# Altium schematic sheet Knot_Thing_NFC_NT3.SchDoc
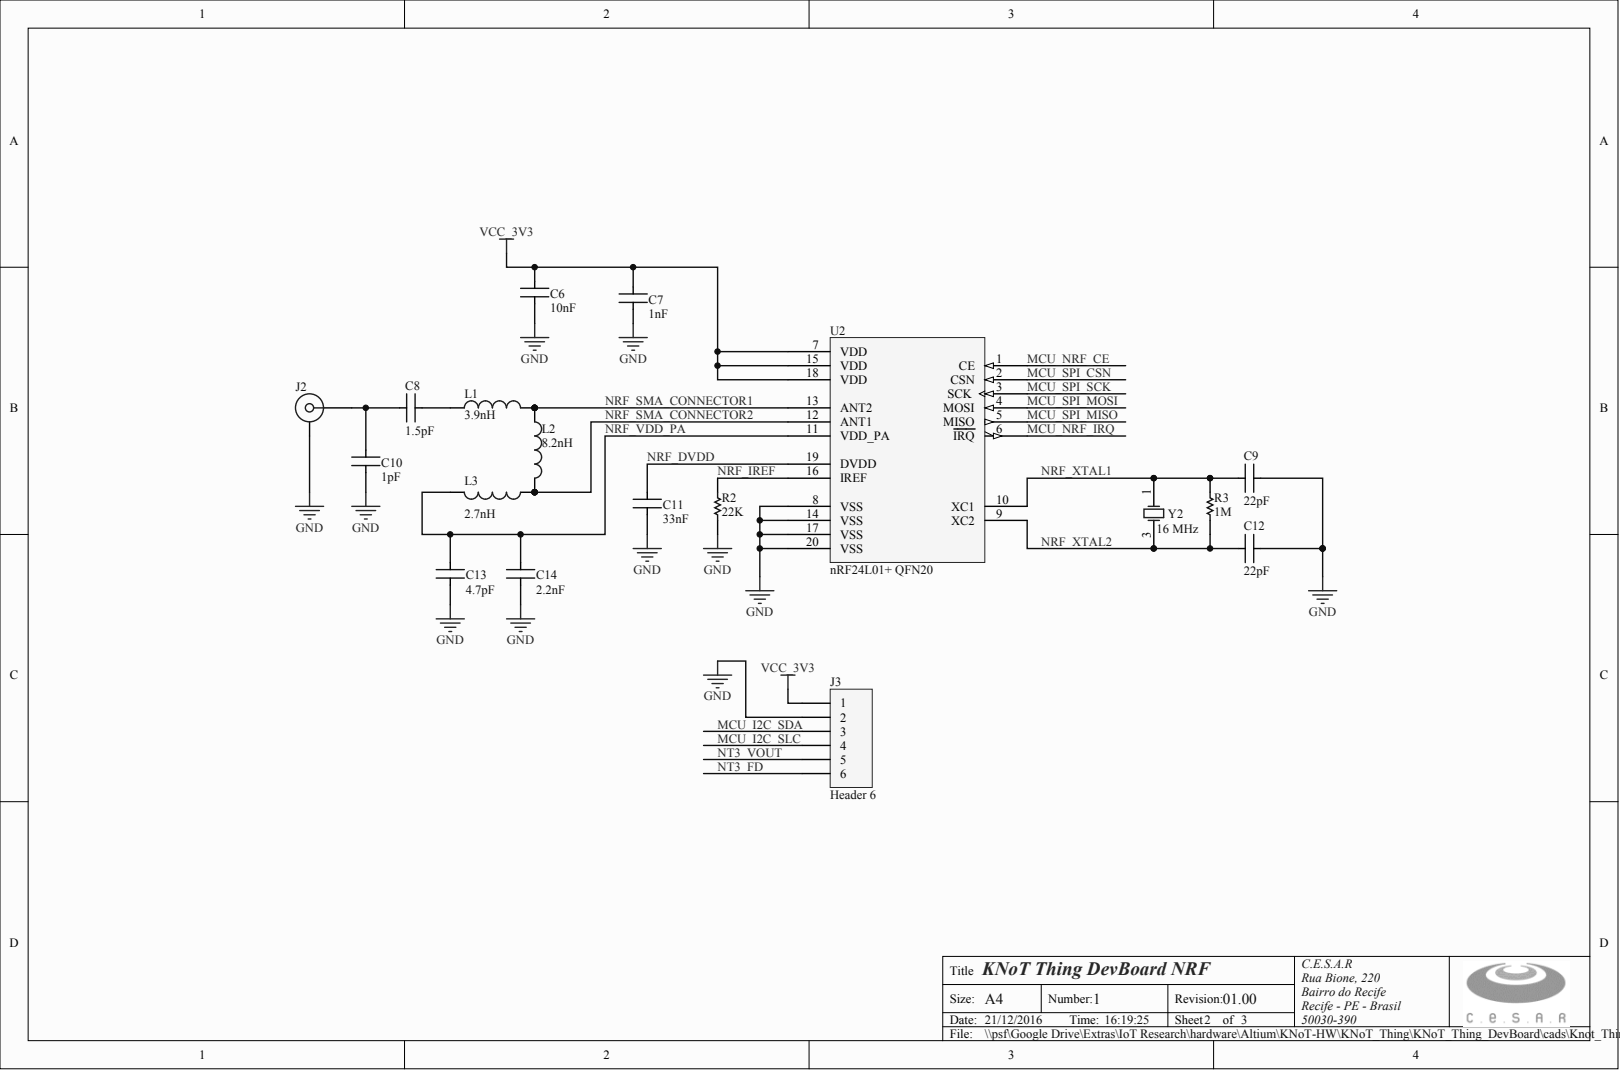
[diagram p0: Knot_Thing_NFC_NT3.SchDoc]

## Parts
C6  value 10nF  (hidden comment: Cap_0402)
C7  value 1nF  (hidden comment: Cap_0402)
C8  value 1.5pF  (hidden comment: Cap_0402)
C9  value 22pF  (hidden comment: Cap_0402)
C10  value 1pF  (hidden comment: Cap_0402)
C11  value 33nF  (hidden comment: Cap_0402)
C12  value 22pF  (hidden comment: Cap_0402)
C13  value 4.7pF  (hidden comment: Cap_0402)
C14  value 2.2nF  (hidden comment: Cap_0402)
J2  32K249-400L5  (value not drawn)
J3  Header 6
L1  Inductor0402  (value not drawn)
L2  Inductor0402  (value not drawn)
L3  Inductor0402  (value not drawn)
R2  value 22K  (hidden comment: RES_0402)
R3  value 1M  (hidden comment: RES_0402)
U2  nRF24L01+ QFN20
Y2  16 MHz

## Nets
GND: C6.1 C7.1 C9.1 C10.1 C11.1 C12.2 C13.1 C14.1 J2.MH J3.2 R2.1 U2.8(VSS) U2.14(VSS) U2.17(VSS) U2.20(VSS) Y2.2 Y2.4
VCC_3V3: C6.2 C7.2 J3.1 U2.7(VDD) U2.15(VDD) U2.18(VDD)
MCU_I2C_SDA: J3.3
MCU_I2C_SLC: J3.4
MCU_NRF_CE: U2.1(CE)
MCU_NRF_IRQ: U2.6(IRQ)
MCU_SPI_CSN: U2.2(CSN)
MCU_SPI_MISO: U2.5(MISO)
MCU_SPI_MOSI: U2.4(MOSI)
MCU_SPI_SCK: U2.3(SCK)
NRF_DVDD: C11.2 U2.19(DVDD)
NRF_IREF: R2.2 U2.16(IREF)
NRF_SMA_CONNECTOR1: L1.2 L2.1 U2.13(ANT2)
NRF_SMA_CONNECTOR2: L2.2 L3.1 U2.12(ANT1)
NRF_VDD_PA: C13.2 C14.2 L3.2 U2.11(VDD_PA)
NRF_XTAL1: C9.2 R3.1 U2.10(XC1) Y2.1
NRF_XTAL2: C12.1 R3.2 U2.9(XC2) Y2.3
NT3_FD: J3.6
NT3_VOUT: J3.5
NetC8_1: C8.1 C10.2 J2.1
NetC8_2: C8.2 L1.1

## Library data of the parts
J2 = (no symbol name): Design Note: Footprint in library is a generic example - only application specific footprint delivers High Performance; DatasheetVersion: b00; PackageVersion: b00; PackageReference: 32K249-400L5; PackageDescription: SMA Right Angle Jack, 14.8 x 6.35 x 9.7 mm; Mounting Technology: Through Hole; PartNumber: 32K249-400L5; ComponentLink1Description: Manufacturer URL; ComponentLink1URL: http://www.rosenberger.de; ComponentLink2Description: Datasheet; ComponentLink2URL: http://rosenberger.de/ok/images/documents/db/32K249-400L5.pdf; ComponentLink3Description: Package Specification; ComponentLink3URL: http://rosenberger.de/ok/images/documents/db/32K249-400L5.pdf; ComponentLink4Description: Series Overview / Design Note; ComponentLink4URL: http://rosenberger.de/ok/images/documents/spez/32.pdf; ComponentLink5Description: Webshop / RFQ; ComponentLink5URL: http://www.rosenberger.com/en/contact/sales/; Supplier 1: Digi-Key; Supplier Part Number 1: ARFX1232-ND
C6-C14 J3 L1-L3 R2 R3 U2 Y2 = (no symbol name)
  C6: Supplier 1: Digi-Key; Supplier Part Number 1: 311-1703-1-ND
  C7: Supplier 1: Digi-Key; Supplier Part Number 1: 311-1352-1-ND
  C8: Supplier 1: Digi-Key; Supplier Part Number 1: 311-1003-1-ND
  C9 C12: Supplier 1: Digi-Key; Supplier Part Number 1: 311-1018-1-ND
  C10: Supplier 1: Digi-Key; Supplier Part Number 1: 311-2076-1-ND
  C11: Supplier 1: Digi-Key; Supplier Part Number 1: 311-1704-1-ND
  C13: Supplier 1: Digi-Key; Supplier Part Number 1: 311-1367-1-ND
  C14: Supplier 1: Digi-Key; Supplier Part Number 1: 311-1707-1-ND
  J3: LatestRevisionDate: 17-Jul-2002; LatestRevisionNote: Re-released for DXP Platform.; Publisher: Altium Limited
  L1: : 3.9nH; Supplier 1: Digi-Key; Supplier Part Number 1: A103711CT-ND
  L2: : 8.2nH; Supplier 1: Digi-Key; Supplier Part Number 1: A102559CT-ND
  L3: : 2.7nH; Supplier 1: Digi-Key; Supplier Part Number 1: A103706CT-ND
  R2: Supplier 1: Digi-Key; Supplier Part Number 1: 311-22KJRCT-ND
  R3: Supplier 1: Digi-Key; Supplier Part Number 1: 311-1.0MJRCT-ND
  U2: Supplier 1: Digi-Key; Supplier Part Number 1: 1490-1033-1-ND
